# Altium schematic sheet StepMotor1.SchDoc
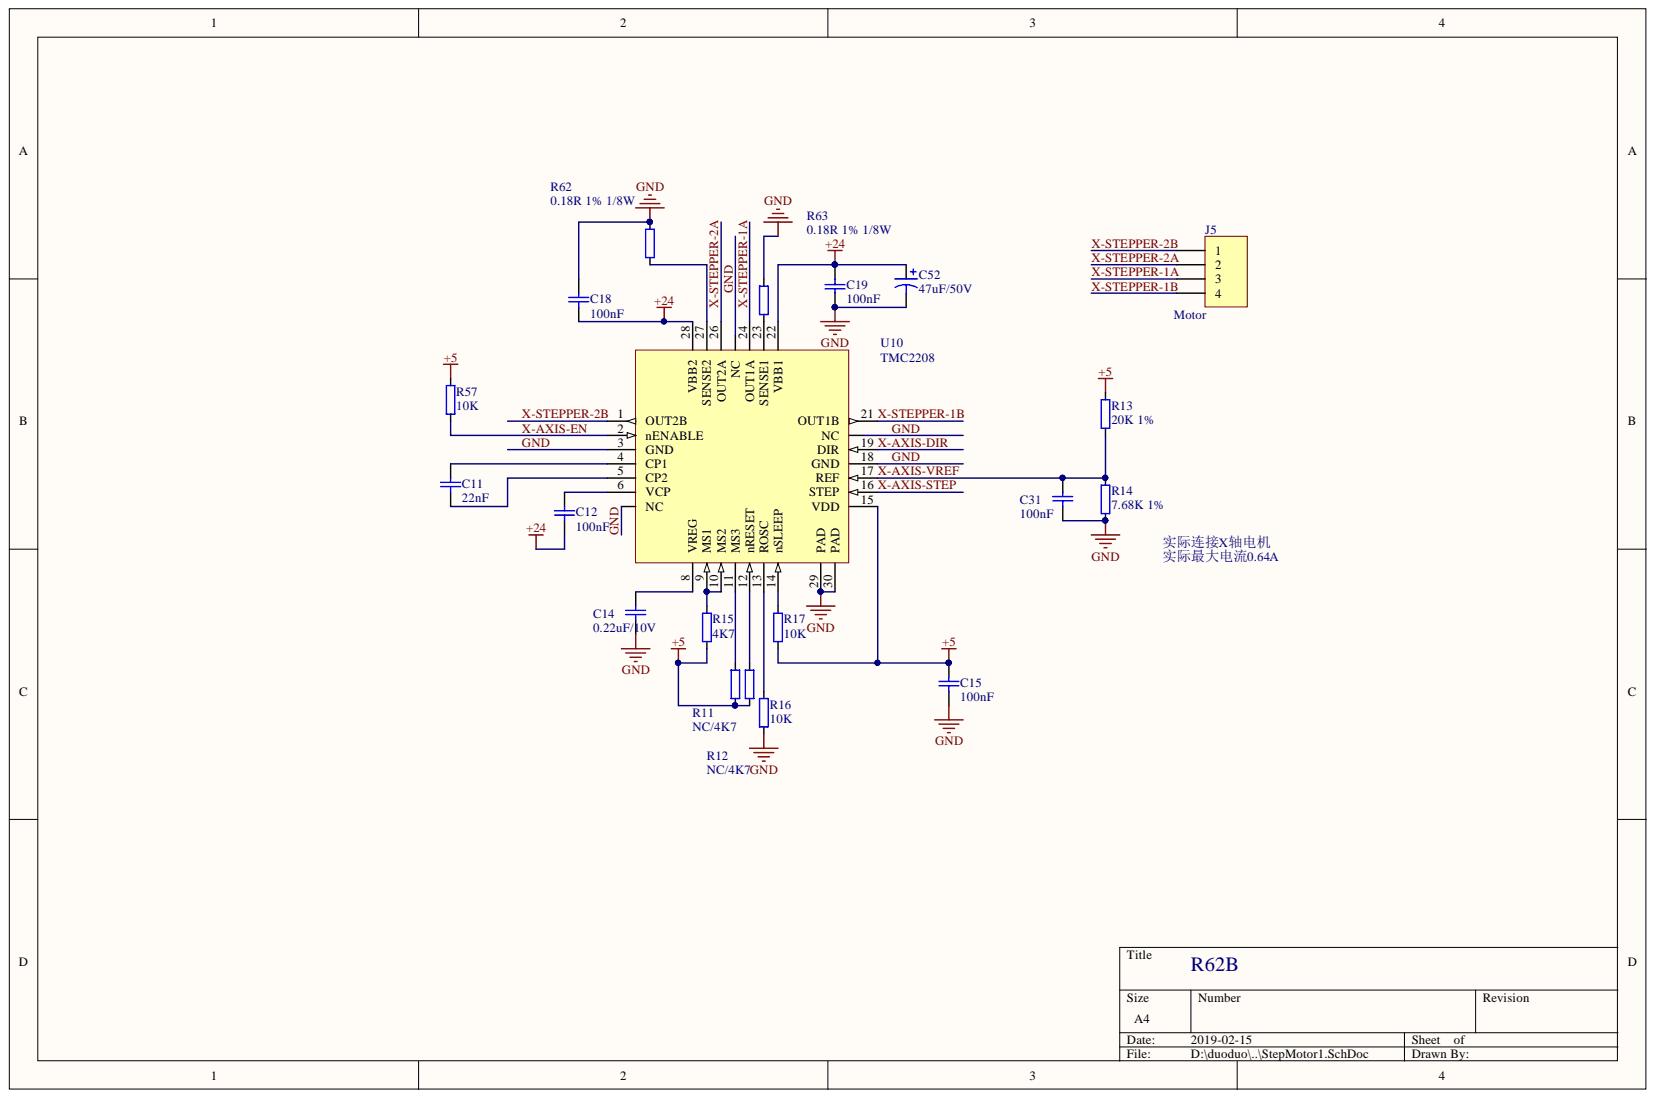
[diagram p0: StepMotor1.SchDoc]

## Parts
C11  22nF  [0603]
C12  100nF  [0603]
C14  0.22uF/10V  [0603]
C15  100nF  [0603]
C18  100nF  [0603]
C19  100nF  [0603]
C31  100nF  [0603]
C52  47uF/50V  [EC-6.3]
J5  ClassName: Motor  [XH2.54-4-A]  (hidden comment: Header 4)
R11  NC/4K7  [0603]
R12  NC/4K7  [0603]
R13  20K 1%  [0603]
R14  7.68K 1%  [0603]
R15  4K7  [0603]
R16  10K  [0603]
R17  10K  [0603]
R57  10K  [0603]
R62  0.18R 1% 1/8W  [0805]
R63  0.18R 1% 1/8W  [0805]
U10  TMC2208  [QFN-28]

## Nets
+5: C15.1 R11.2 R12.2 R13.2 R15.2 R17.2 R57.2 U10.15(VDD)
+24: C12.2 C18.2 C19.2 C52.1 U10.22(VBB1) U10.28(VBB2)
GND: C14.2 C15.2 C18.1 C19.1 C31.2 C52.2 R14.2 R16.2 R62.2 R63.2 U10.3(GND) U10.7(NC) U10.18(GND) U10.20(NC) U10.25(NC) U10.29(PAD) U10.30(PAD)
X-AXIS-DIR: U10.19(DIR)
X-AXIS-EN: R57.1 U10.2(nENABLE)
X-AXIS-STEP: U10.16(STEP)
X-AXIS-VREF: C31.1 R13.1 R14.1 U10.17(REF)
X-STEPPER-1A: J5.3 U10.24(OUT1A)
X-STEPPER-1B: J5.4 U10.21(OUT1B)
X-STEPPER-2A: J5.2 U10.26(OUT2A)
X-STEPPER-2B: J5.1 U10.1(OUT2B)
NetC11_1: C11.1 U10.4(CP1)
NetC11_2: C11.2 U10.5(CP2)
NetC12_1: C12.1 U10.6(VCP)
NetC14_1: C14.1 U10.8(VREG)
NetR11_1: R11.1 U10.11(MS3)
NetR12_1: R12.1 U10.12(nRESET)
NetR15_1: R15.1 U10.9(MS1) U10.10(MS2)
NetR16_1: R16.1 U10.13(ROSC)
NetR17_1: R17.1 U10.14(nSLEEP)
NetR62_1: R62.1 U10.27(SENSE2)
NetR63_1: R63.1 U10.23(SENSE1)

## Library data of the parts
C11 C12 C14 C15 C18 C19 C31 = CAP: Capacitor; Component Kind: Standard; Library Name: DMSch.SCHLIB
C52 = Cap Pol1: Polarized Capacitor (Radial); Component Kind: Standard; Library Name: Miscellaneous Devices.IntLib; Signal Integrity: Cap Pol; Simulation: CAP
J5 = Header 4: Header, 4-Pin; Component Kind: Standard; LatestRevisionDate: 17-Jul-2002; LatestRevisionNote: Re-released for DXP Platform.; Library Name: Miscellaneous Connectors.IntLib; Signal Integrity: Connector; Publisher: Altium Limited
R11-R17 R57 R62 R63 = RES3: Component Kind: Standard; Library Name: DMSch.SCHLIB
U10 = A4988-QFN28: A4982 Step Driver; Component Kind: Standard; Library Name: DMSch.SCHLIB
